# Altium schematic sheet Nucleo.SchDoc(Nucleo)
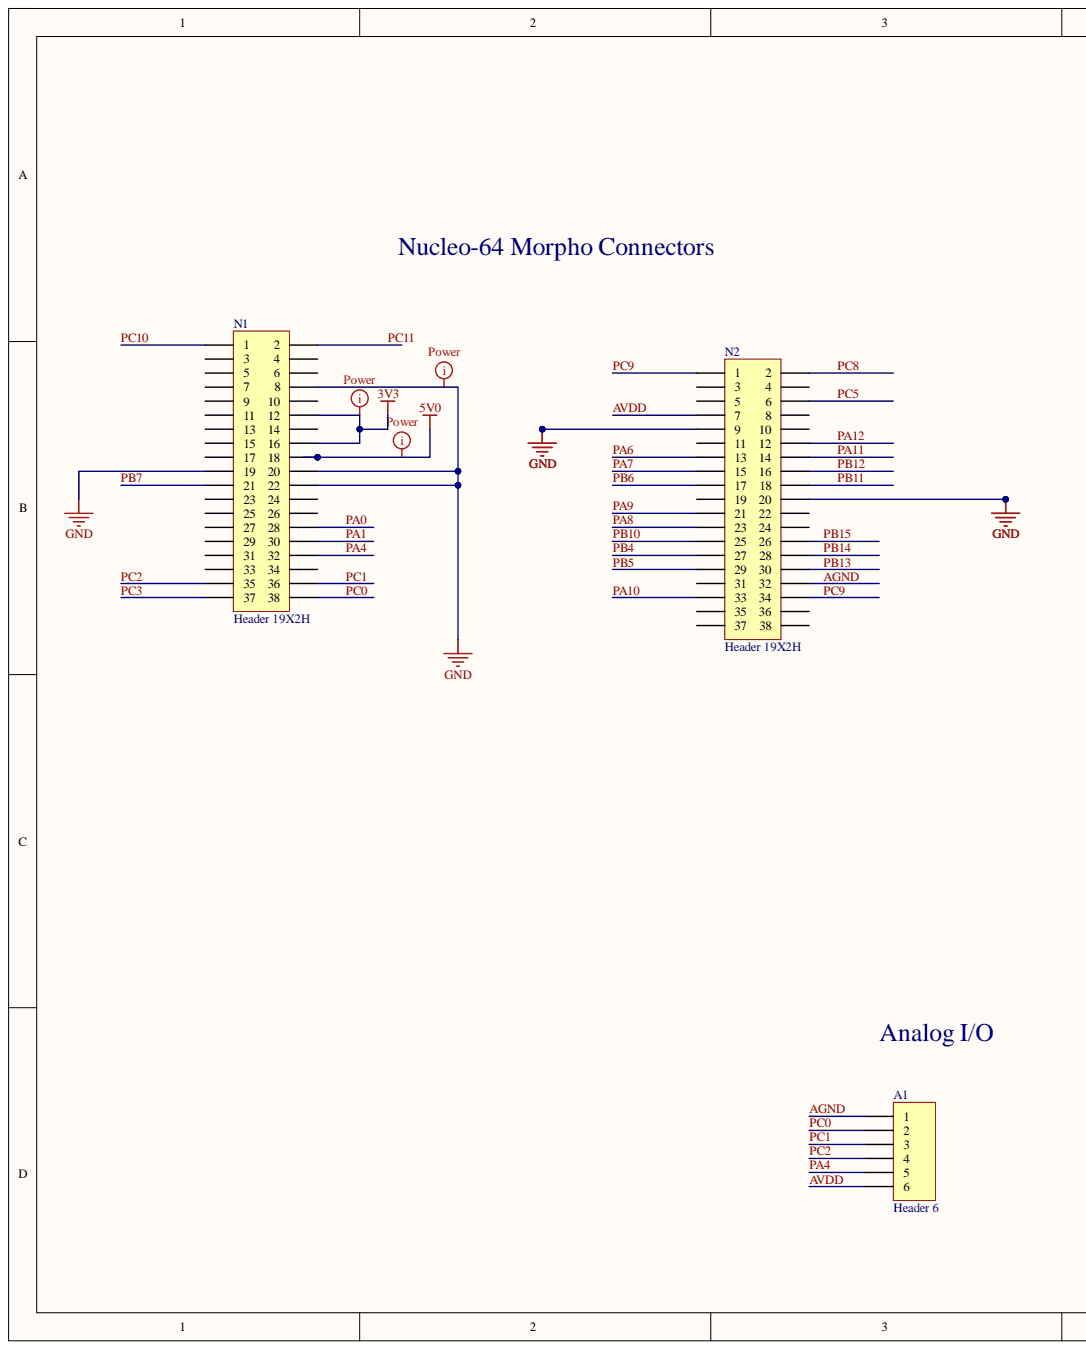
[diagram p0: Nucleo.SchDoc, left]
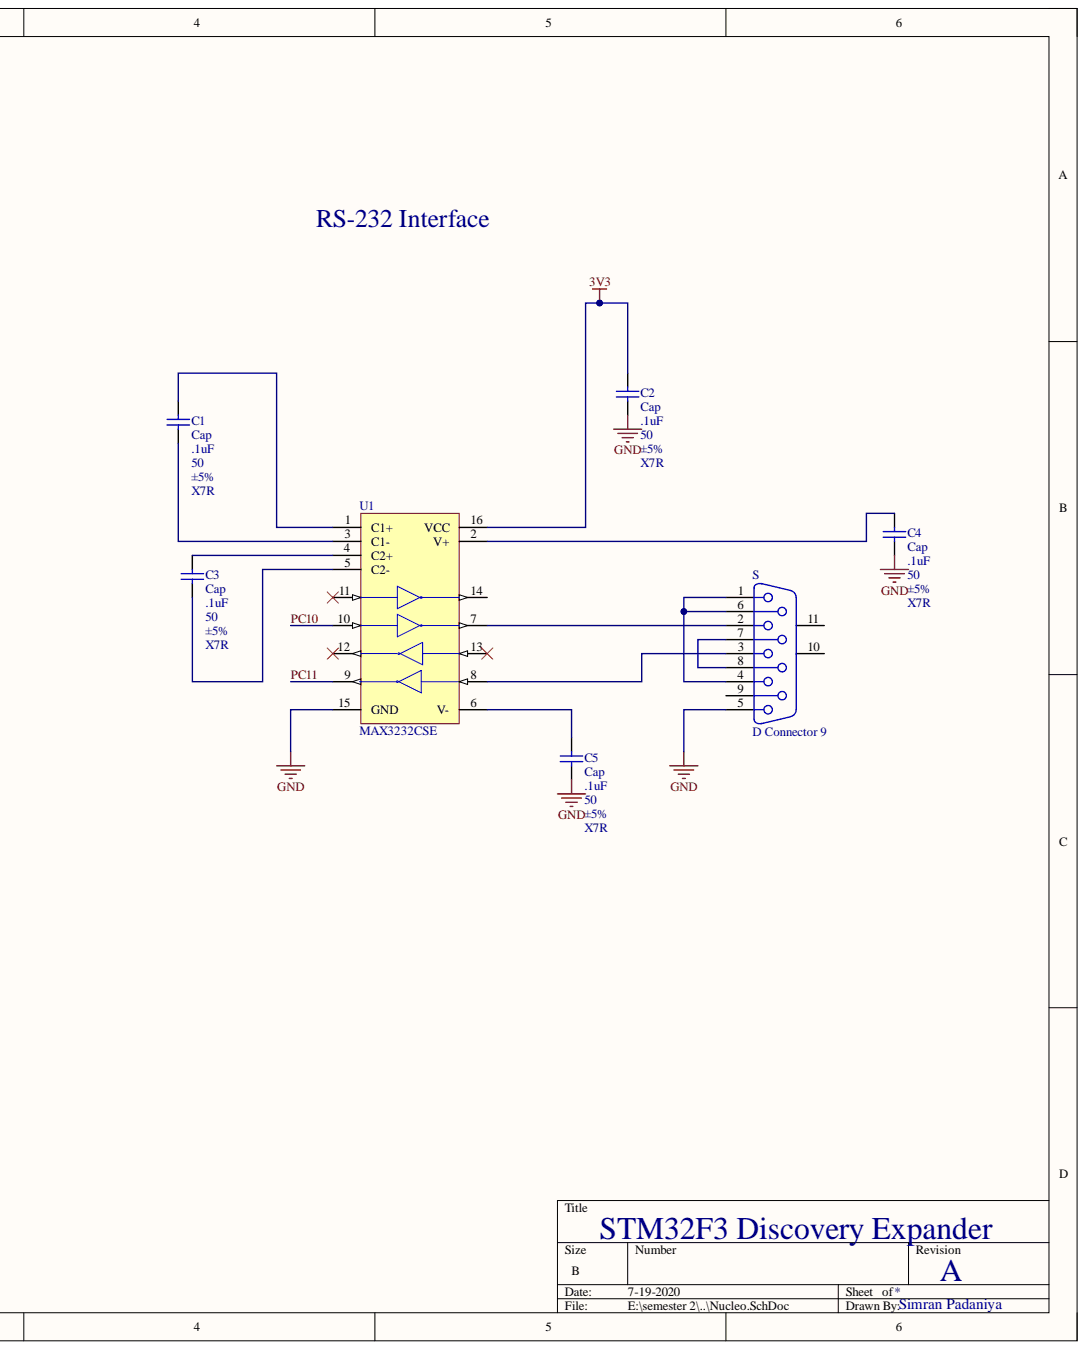
[diagram p1: Nucleo.SchDoc, right]

## Parts
A1  Header 6  [HDR1X6]
C1  Cap  value .1uF  Tolerance: ±5%  [CAPC2012X09M]
C2  Cap  value .1uF  Tolerance: ±5%  [CAPC2012X09M]
C3  Cap  value .1uF  Tolerance: ±5%  [CAPC2012X09M]
C4  Cap  value .1uF  Tolerance: ±5%  [CAPC2012X09M]
C5  Cap  value .1uF  Tolerance: ±5%  [CAPC2012X09M]
N1  Header 19X2H  [PPPC192LFBN-RC]
N2  Header 19X2H  [PPPC192LFBN-RC]
S  D Connector 9  [DSUB1.385-2H9]
U1  MAX3232CSE  [NSO16_N]

## Nets
3V3: C2.2 N1.12 N1.16 U1.16(VCC)
5V0: N1.18
GND: C2.1 C4.1 C5.1 N1.8 N1.19 N1.20 N1.22 N2.9 N2.20 S.5 U1.15(GND)
AGND: A1.1 N2.32
AVDD: A1.6 N2.7
PA0: N1.28
PA1: N1.30
PA4: A1.5 N1.32
PA6: N2.13
PA7: N2.15
PA8: N2.23
PA9: N2.21
PA10: N2.33
PA11: N2.14
PA12: N2.12
PB4: N2.27
PB5: N2.29
PB6: N2.17
PB7: N1.21
PB10: N2.25
PB11: N2.18
PB12: N2.16
PB13: N2.30
PB14: N2.28
PB15: N2.26
PC0: A1.2 N1.38
PC1: A1.3 N1.36
PC2: A1.4 N1.35
PC3: N1.37
PC5: N2.6
PC8: N2.2
PC9: N2.1 N2.34
PC10: N1.1 U1.10
PC11: N1.2 U1.9
NetC1_1: C1.1 U1.3(C1-)
NetC1_2: C1.2 U1.1(C1+)
NetC3_1: C3.1 U1.5(C2-)
NetC3_2: C3.2 U1.4(C2+)
NetC4_2: C4.2 U1.2(V+)
NetC5_2: C5.2 U1.6(V-)
NetS_1: S.1 S.4 S.6
NetS_2: S.2 U1.7
NetS_3: S.3 U1.8
NetS_7: S.7 S.8

Not connected: N1 3 4 5 6 7 9 10 11 13 14 15 17 23 24 25 26 27 29 31 33 34; N2 3 4 5 8 10 11 19 22 24 31 35 36 37 38; S 9 10 11; U1 11 12 13 14

## Library data of the parts
A1 = Header 6: Header, 6-Pin; Component Kind: Standard; LatestRevisionDate: 17-Jul-2002; LatestRevisionNote: Re-released for DXP Platform.; Library Name: Miscellaneous Connectors.IntLib; Signal Integrity: Connector; Publisher: Altium Limited
C1-C5 = CAP-X7R-.1uF-50V-±5%: CAP CER .1uF 50v X7R 0805; MPN: C0805C104J5RACTU; PN: 399-1171-1-ND; WV: 50; Component Kind: Standard; Library Name: esd_library.IntLib; Name: CAP-X7R-.1uF-50V-±5%; Type: X7R; URL: http://www.digikey.ca/product-detail/en/C0805C104J5RACTU/399-1171-1-ND
N1 N2 = Header 19X2H: 38 Position Header Connector 0.100in (2.54mm) Through Hole Gold; PN: S7122-ND; Component Kind: Standard; Library Name: esd_library.IntLib; MPN: PPPC192LFBN-RC; Signal Integrity: Connector; URL: https://www.digikey.ca/product-detail/en/sullins-connector-solutions/PPPC192LFBN-RC/S7122-ND
S = D Connector 9: Receptacle Assembly, 9 Position, Right Angle; MPN: ADF09A-KG-TASB3-R; PN: AE10921-ND; Component Kind: Standard; Library Name: esd_library.IntLib; Signal Integrity: Connector; URL: http://www.digikey.ca/product-detail/en/ADF09A-KG-TASB3-R/AE10921-ND
U1 = MAX3232CSE: 3.0V TO 5.5V, Low-Power, up to 1Mbps, True RS-232 Transceiver Using Four 0.1µF External Capacitor; MPN: MAX3232CSE+; PN: MAX3232CSE+-ND; Code_IPC: SOIC127P600-16; Code_JEDEC: MS-012-AC; Component Kind: Standard; ComponentLink1Description: Manufacturer Link; ComponentLink1URL: http://www.maxim-ic.com/; ComponentLink2Description: Datasheet; ComponentLink2URL: http://pdfserv.maxim-ic.com/en/ds/MAX3222-MAX3241.pdf; DatasheetVersion: Mar-1999; LatestRevisionDate: 13-Apr-2006; LatestRevisionNote: IPC-7351 Footprint Added.; Library Name: esd_library.IntLib; PackageDescription: 16-Pin Small Outline Integrated Circuit 1.27 mm Pitch; PackageReference: NSO16; PCB3D: NSO16; Published: 16-Jan-2001; URL: http://www.digikey.ca/product-detail/en/MAX3232CSE%2B/MAX3232CSE%2B-ND; Publisher: Altium Limited
